# Altium schematic sheet Switch PCB Schematic.SchDoc("Switch PCB Schematic")
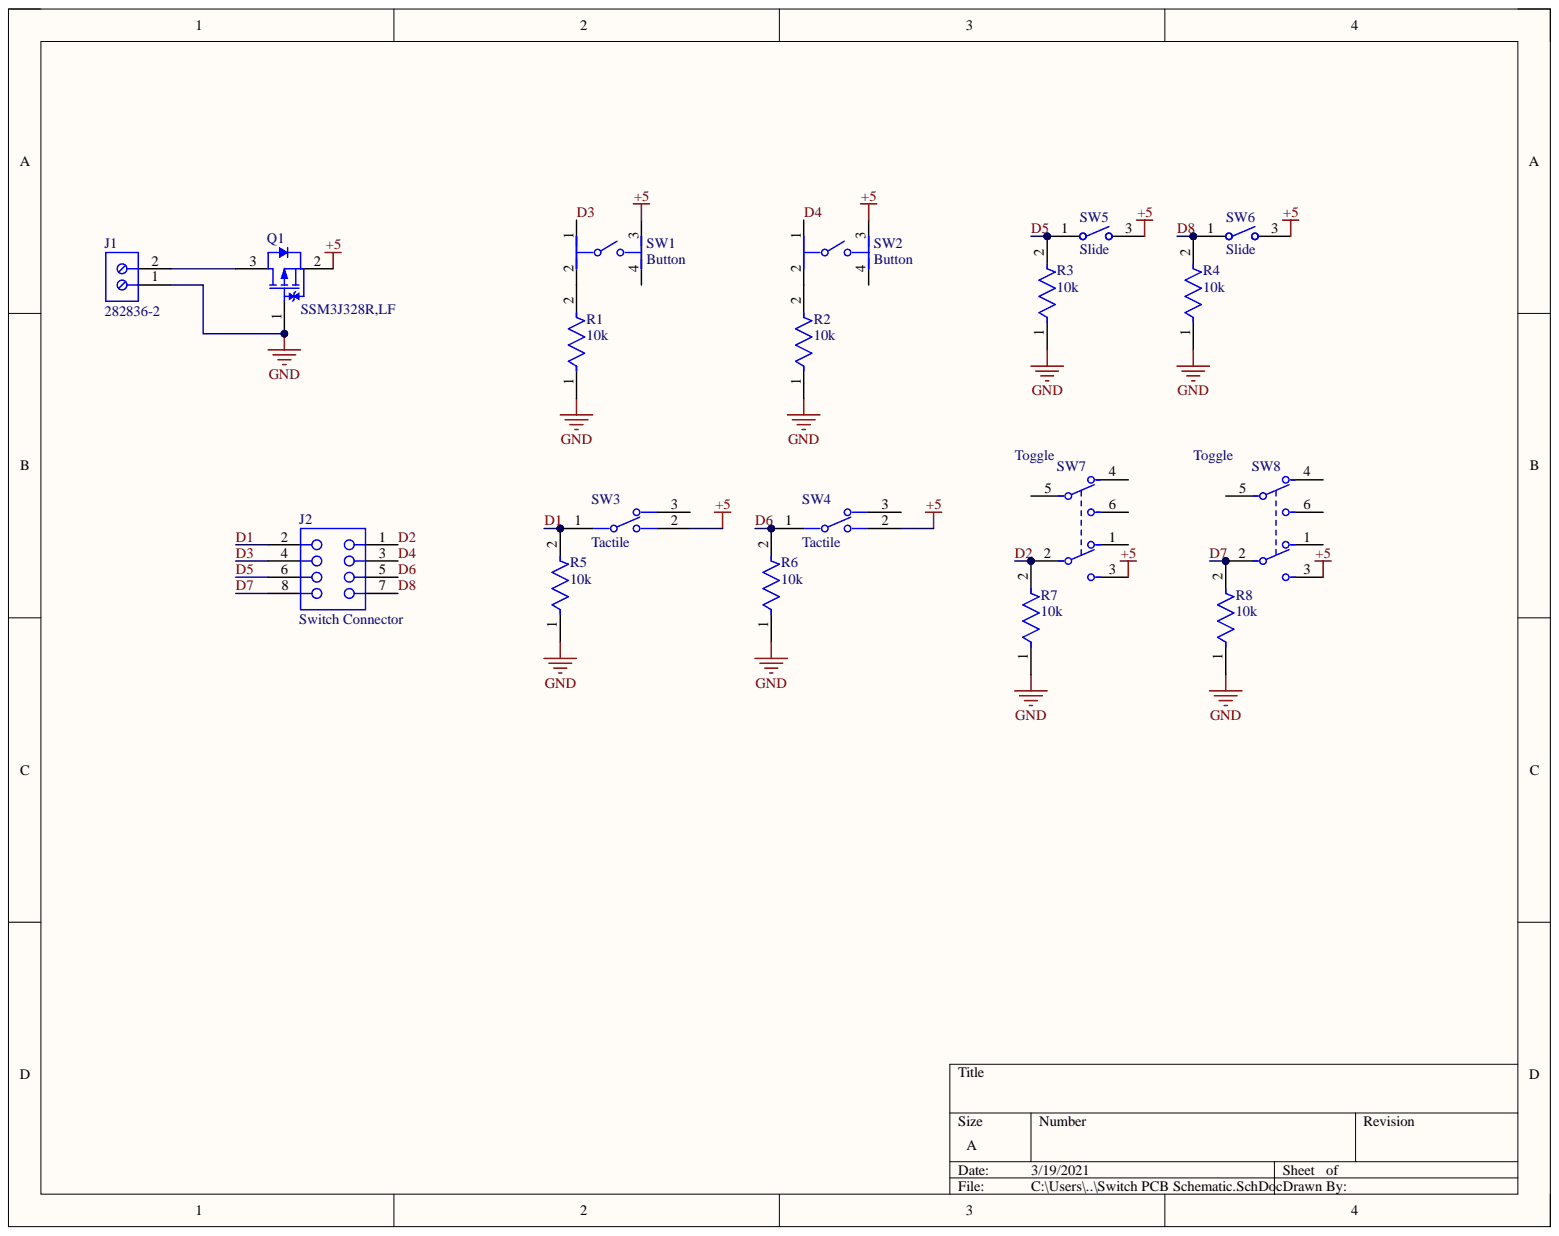
[diagram p0: Switch PCB Schematic.SchDoc]

## Parts
J1  282836-2  [TECO-282836-2_V]
J2  Switch Connector  [SMTC-SSW-104-01-X-D]
Q1  SSM3J328R,LF  [TOSH-SOT-23F_V]
R1  10k  [FP-RT1206-MFG]
R2  10k  [FP-RT1206-MFG]
R3  10k  [FP-RT1206-MFG]
R4  10k  [FP-RT1206-MFG]
R5  10k  [FP-RT1206-MFG]
R6  10k  [FP-RT1206-MFG]
R7  10k  [FP-RT1206-MFG]
R8  10k  [FP-RT1206-MFG]
SW1  Button  [FP-1825910-2-0]
SW2  Button  [FP-1825910-2-0]
SW3  Tactile  [FP-SS-5GL2D-MFG]
SW4  Tactile  [FP-SS-5GL2D-MFG]
SW5  Slide  [CKCP-TS02CBE_V]
SW6  Slide  [CKCP-TS02CBE_V]
SW7  Toggle  [FP-100DP1T2B3M2QEH-MFG]
SW8  Toggle  [FP-100DP1T2B3M2QEH-MFG]

## Nets
+5: Q1.2 SW1.3 SW2.3 SW3.2 SW4.2 SW5.3 SW6.3 SW7.3 SW8.3
GND: J1.1 Q1.1 R1.1 R2.1 R3.1 R4.1 R5.1 R6.1 R7.1 R8.1
~{}: J2.7 R4.2 SW6.1
D1: J2.2 R5.2 SW3.1
D2: J2.1 R7.2 SW7.2
D3: J2.4 SW1.1
D4: J2.3 SW2.1
D5: J2.6 R3.2 SW5.1
D6: J2.5 R6.2 SW4.1
D7: J2.8 R8.2 SW8.2
NetJ1_2: J1.2 Q1.3
NetR1_2: R1.2 SW1.2
NetR2_2: R2.2 SW2.2

Not connected: SW1 4; SW2 4; SW3 3; SW4 3; SW7 1 4 5 6; SW8 1 4 5 6

## Library data of the parts
J1 = CMP-1684-00017-1: Female Header, Pitch 5 mm, 1 x 2 Position, Height 10 mm, Tail Length 3.5 mm, Rohs, Bulk; Manufacturer: TE Connectivity; 3D Model: 282836-2.pdf; Clamp Material - Plating: Brass-NickelPlated; Current: 13.5A; Dynamic Catalog: BuchananSeries; Featured Product: EurostyleTerminalBlockConnectors; Mating Orientation: HorizontalwithBoard; Number of Levels: 1; Other Names: 5ULV-02B  A98076; Pitch: 0.197"(5.00mm); Positions Per Level: 2; Product Training Modules: Wire-to-BoardEurostyleTerminalBlocks; Screw Thread: M3; Torque - Screw: 0.68Nm(6Lb-In); Voltage: 300V; Wire Gauge: 16-30AWG; Wire Termination: Screw-RisingCageClamp; Category: Connectors,Interconnects; Color: Green; Component Kind: Standard; Contact Material - Plating: Brass-TinPlated; Datasheet URL: http://www.te.com.cn/commerce/DocumentDelivery/DDEController?Action=showdoc&DocId=Catalog+Section%7F1308389_EUROSTYLE_TERMINAL_BLOCKS%7F0607%7Fpdf%7FEnglish%7FENG_CS_1308389_EUROSTYLE_TERMINAL_BLOCKS_0607.pdf%7F282836-2; Family: TerminalBlocks-WiretoBoard; Features: Interlocking(Side); Housing Material: Polyamide(PA66),Nylon6/6; Library Name: Altium Content Vault; Manufacturer URL: http://www.te.com; Mounting Type: ThroughHole; Package Description: Female Header, Pitch 5 mm, 1 x 2 Position, Height 10 mm, Tail Length 3.5 mm; Package Reference: THD; Packaging: Bulk; RoHS Information: 282836-2StatementofCompliance; Screw Material - Plating: Brass-NickelPlated; Series: Buchanan; Standard Package: 300
J2 = CMP-1023-00339-1: SQ Post Socket, Through-hole, Vertical, -55 to 105 degC, 2.54mm Pitch, 8-Pin, Female, RoHS; Manufacturer: Samtec; PartNumber: SSW-104-01-T-D; Component Kind: Standard; ComponentLink1Description: Manufacturer URL; ComponentLink1URL: http://www.samtec.com; ComponentLink2Description: Datasheet; ComponentLink2URL: http://www.samtec.com/documents/webfiles/pdf/SSW_TH.PDF; ComponentLink3Description: Package Specification; ComponentLink3URL: http://www.samtec.com/documents/webfiles/cpdf/SSW-1XX-XX-XXX-X-XX-XXX-XX-MKT.pdf; DatasheetVersion: F-214; Library Name: Altium Content Vault; Mounting Technology: Through-hole; Orientation: Vertical; PackageDescription: Assembly, Socket Strip .016 X .031 Tail; PackageReference: SSW-104-01-X-D; PackageVersion: CA, 6/1987; Pitch: 2.54 mm; RoHS: True; Temperature Range: -55 to 105 degC
Q1 = CMP-2100-03686-1: TOSHIBA Field-Effect Transistor Silicon P-Channel MOS Type (U-MOS VI), 20 V, -55 to 125 degC, 3-Pin SOTFL, RoHS, Tape and Reel; Continuous Drain Current (ID): 6A; Drain to Source Breakdown Voltage: -20V; Drain to Source Resistance: 47.4mOhm; Drain to Source Voltage (Vdss): 20V; Element Configuration: Single; Gate to Source Voltage (Vgs): 8V; Input Capacitance: 840pF; Max Power Dissipation: 1W; Number of Channels: 1; Power Dissipation: 2W; Rds On Max: 29.8mOhm; Turn-Off Delay Time: 107ns; Turn-On Delay Time: 32ns; Case/Package: SOT-23-3; Component Kind: Standard; Datasheet URL: https://toshiba.semicon-storage.com/info/docget.jsp?did=2429&prodName=SSM3J328R; Datasheet Version: 41699.0; Library Name: Altium Content Vault; Manufacturer: Toshiba; Manufacturer URL: http://www.toshiba.com/; Max Operating Temperature: 150degC; Min Operating Temperature: -55degC; Mount: SurfaceMount; Number of Elements: 1; Number of Pins: 3; Package Description: 3-Pin Small Outline Transistor, Flat Lead, Body 2.9 x 1.8 mm, Pitch 0.95 mm; Package Quantity: 3000; Package Reference: SOT-23F; Package URL: https://toshiba.semicon-storage.com/ap-en/design-support/package/detail.SOT-23F.html; Packaging: TapeandReel; Radiation Hardening: No; RoHSCompliant: true
R1-R8 = CMP-2003-04268-2: RES SMD 10K OHM 0.5% 1/4W 1206; Manufacturer: Yageo; Manufacturer Part Number: RT1206DRE0710KL; Power Rating: 0.25W; Resistance: 10KΩ; Temperature Coefficient: 50 ppm/°C; Tolerance: 0.0001; Value: 10KΩ; Voltage Rating: 200V; Automotive: No; Category: Res; Component Kind: Standard; ComponentLink2Description: Datasheet; ComponentLink2URL: http://www.yageo.com/documents/recent/PYu-RT_1-to-0.01_RoHS_L_9.pdf; Composition: Thin Film; Device Class L1: Passive Components; Device Class L2: Resistors; Device Class L3: Chip SMD Resistors; Digikey Description: RES SMD 10K OHM 0.5% 1/4W 1206; Footprint Url: http://www.yageo.com/exep/pages/download/literatures/PYu-R_Mount_10.pdf; Height: 0.65mm; Ipc Land Pattern Name: RESC310160X55; Lead Free: Yes; Library Name: Altium Content Vault; Octopart: https://octopart.com/rt1206dre0710kl-yageo-1219301; Package: RT1206; RoHS: TRUE; Series: RT; Temperature: -55°C to +155°C; Temperature Range High: +155°C; Temperature Range Low: -55°C
SW1 SW2 = CMP-03407-000004-1: SWITCH TACTILE SPST-NO 0.05A 24V. Push button; Manufacturer: TE; Manufacturer Part Number: 1825910-2; Contact Current Rating: 50mA; Contact Resistance: 100mΩ; Electromechanical Life: 100000Cycles; Operating Force: 160gf; Voltage Rating Dc: 24V; Automotive: No; Category: Switch; Component Kind: Standard; ComponentLink2Description: Datasheet; ComponentLink2URL: https://www.te.com/commerce/DocumentDelivery/DDEController?Action=showdoc&DocId=Customer+Drawing%7F1825910%7FC10%7Fpdf%7FEnglish%7FENG_CD_1825910_C10.pdf%7F1825910-2; Device Class L1: Electromechanical; Device Class L2: Switches; Device Class L3: Tactile Switches; Digikey Description: SWITCH TACTILE SPST-NO 0.05A 24V; Height: 4.6mm; Lead Free: Yes; Library Name: Altium Content Vault; Mount: Through Hole; Octopart: https://octopart.com/1825910-2-te+connectivity-42270502; Package: 0; RoHS: TRUE; Temperature: -35°C to +85°C; Temperature Range High: +85°C; Temperature Range Low: -35°C; Throw Configuration: SPST-NO
SW3 SW4 = CMP-08833-000010-1: SWITCH SNAP ACTION SPDT 5A 125V. Snap Action; Actuator Material: Stainless Steel; Differential Travel: 0.031inch; Electrical Life: 200000Cycles; Max Voltage Rating (AC): 125V; Max Voltage Rating (DC): 14V; Mechanical Life: 30000000Cycles; Operating Force: 0.49N; Operating Position: 0.571inch; Overtravel: 0.047inch; Ratings: IP40; Switch Function: On-Mom; Voltage Rating (DC): 30V; Component Kind: Standard; Contact Current Rating: 5A; Contact Material: Silver; Current Rating: 5A; Depth: 6.4mm; Lead Free: Lead Free; Length: 19.8mm; Library Name: Altium Content Vault; Max Current Rating: 5A; Max Operating Temperature: 85°C; Min Operating Temperature: -25°C; Mount: Through Hole; Orientation: Straight; Package Quantity: 500; Packaging: Bulk; REACH SVHC: No SVHC; Termination: PC Pins; Throw Configuration: SPDT; Voltage Rating (AC): 125V
SW5 SW6 = CMP-2000-07317-1: Tiny Slide Switch, SPST, 20 V, -30 to 85 degC, 2-Pin THD, RoHS, Bulk Slide Switch; Manufacturer: C&K Components; AC Voltage Rating (Max): 20V; Actuator Material: Nylon; Actuator Style: TopSlide; Product Depth (mm): 5.08mm; Product Height (mm): 12.91mm; Product Length (mm): 10.16mm; Switch Configuration: OFFNoneON; Component Kind: Standard; Contact Material: CopperAlloy; Contact Plating: Gold/Nickel; Datasheet URL: http://www.ck-components.com/media/1426/ts_12feb16.pdf; Datasheet Version: 02/2016; DC Voltage Rating (Max): 20V; Housing Material: N/A/StainlessSteel; Library Name: Altium Content Vault; Manufacturer URL: http://www.ckswitches.com/; Mounting Style: ThroughHole; Mounting Technology: ThroughHole; Operating Temp Range: -30Cto85C; Package Description: 2-Pin Through Hole Device, Body 10.16 x 5.08 mm; Package Reference: TS02CBE; Pole Throw Configuration: SPST; Terminal Type: PCPins; Type: Tiny
SW7 SW8 = CMP-17880-000002-1: SWITCH TOGGLE NON ILLUMINATED. Double Pole Single Throw; Manufacturer: E-Switch; Manufacturer Part Number: 100DP1T2B3M2QEH; Contact Current Rating: 5A; Contact Resistance: 10mΩ; Electromechanical Life: 40000Cycles; Throw Configuration: DPDT; Voltage Rating Ac: 120V; Voltage Rating Dc: 28V; Automotive: No; Category: Switch; Component Kind: Standard; ComponentLink2Description: Datasheet; ComponentLink2URL: http://spec_sheets.e-switch.com/specs/ST121094.pdf; Device Class L1: Electromechanical; Device Class L2: Switches; Device Class L3: Toggle Switches; Digikey Description: SWITCH TOGGLE NON ILLUMINATED; Footprint Url: http://spec_sheets.e-switch.com/specs/ST121094.pdf; Height: 23.6mm; Lead Free: Yes; Library Name: Altium Content Vault; Mount: Through Hole; Mouser Description: Toggle Switches 5A 120VAC/28VDC On-None-On PC Mnt; Octopart: https://octopart.com/100dp1t2b3m2qeh-e-switch-7054946; Package: 0; RoHS: TRUE; Temperature: -30°C to +85°C; Temperature Range High: +85°C; Temperature Range Low: -30°C
